# Altium schematic sheet MinSys.SchDoc
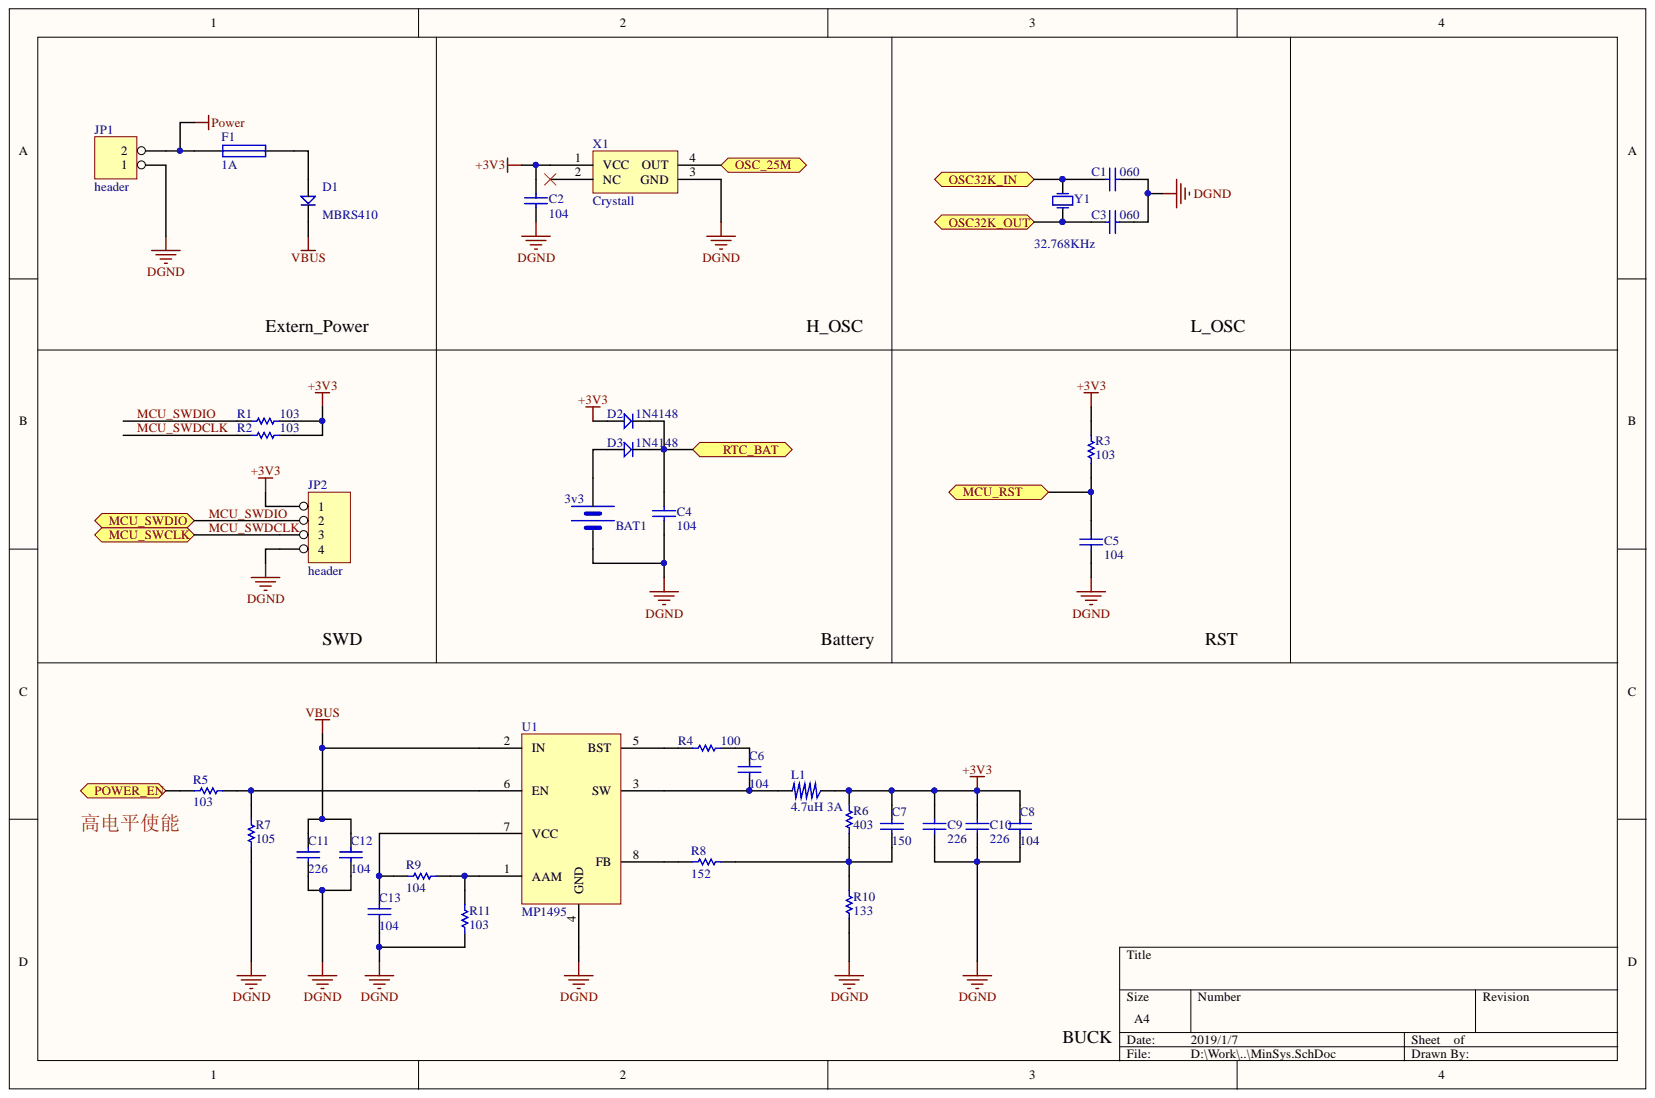
[diagram p0: MinSys.SchDoc]

## Parts
BAT1  3v3  [BATM]
C1  060  [0603_C]
C2  104  [0603_C]
C3  060  [0603_C]
C4  104  [0603_C]
C5  104  [0603_C]
C6  104  [0603_C]
C7  150  [0603_C]
C8  104  [0603_C]
C9  226  [0805_c]
C10  226  [0805_c]
C11  226  [0805_c]
C12  104  [0603_C]
C13  104  [0603_C]
D1  MBRS410  [SMC]
D2  1N4148  [DIODE_SOP]
D3  1N4148  [DIODE_SOP]
F1  1A  [0805]
JP1  header  [header2]
JP2  header  [header4]
L1  4.7uH 3A  [L_CD43]
R1  103  [0603_R]
R2  103  [0603_R]
R3  103  [0603_R]
R4  100  [0603_R]
R5  103  [0603_R]
R6  403  [0603_R]
R7  105  [0603_R]
R8  152  [0603_R]
R9  104  [0603_R]
R10  133  [0603_R]
R11  103  [0603_R]
U1  MP1495  [TSOT23-8]
X1  Crystall  [cpx-49s]
Y1  32.768KHz  [XTAL3215]

## Ports
MCU_RST, MCU_SWCLK, MCU_SWDIO, OSC32K_IN, OSC32K_OUT, OSC_25M, POWER_EN, RTC_BAT

## Nets
+3V3: C2.1 C7.2 C8.2 C9.2 C10.2 D2.1 JP2.1 L1.1 R1.2 R2.2 R3.2 R6.2 X1.1(VCC)
DGND: BAT1.2 C1.2 C2.2 C3.2 C4.1 C5.1 C8.1 C9.1 C10.1 C11.1 C12.1 C13.1 JP1.1 JP2.4 R7.1 R10.1 R11.1 U1.4(GND) X1.3(GND)
POWER: F1.2 JP1.2
VBUS: C11.2 C12.2 D1.2 U1.2(IN)
MCU_RST: C5.2 R3.1 ; port MCU_RST
MCU_SWDCLK: JP2.3 R2.1 ; port MCU_SWCLK
MCU_SWDIO: JP2.2 R1.1 ; port MCU_SWDIO
OSC32K_IN: C1.1 Y1.2 ; port OSC32K_IN
OSC32K_OUT: C3.1 Y1.1 ; port OSC32K_OUT
OSC_25M: X1.4(OUT) ; port OSC_25M
POWER_EN: R5.2 ; port POWER_EN
RTC_BAT: C4.2 D2.2 D3.2 ; port RTC_BAT
NetBAT1_1: BAT1.1 D3.1
NetC6_1: C6.1 L1.2 U1.3(SW)
NetC6_2: C6.2 R4.2
NetC7_1: C7.1 R6.1 R8.2 R10.2
NetC13_2: C13.2 R9.2 U1.7(VCC)
NetD1_1: D1.1 F1.1
NetR4_1: R4.1 U1.5(BST)
NetR5_1: R5.1 R7.2 U1.6(EN)
NetR8_1: R8.1 U1.8(FB)
NetR9_1: R9.1 R11.2 U1.1(AAM)

Not connected: X1 2(NC)

## Library data of the parts
BAT1 = BAT: Component Kind: Standard; Library Name: 阿波罗STM32F4&F7底板封装库.IntLib
C1 C3 C7 C9-C11 = CAP: Capacitor; Value: 104; Component Kind: Standard; LatestRevisionDate: 17-Jul-2002; LatestRevisionNote: Re-released for DXP Platform.; Library Name: My Footprint.IntLib; PackageReference: RAD-0.3; Published: 8-Jun-2000; Publisher: Altium Limited
C2 C4-C6 C8 C12 C13 = CAP: Capacitor; Component Kind: Standard; LatestRevisionDate: 17-Jul-2002; LatestRevisionNote: Re-released for DXP Platform.; Library Name: My Footprint.IntLib; PackageReference: RAD-0.3; Published: 8-Jun-2000; Value: 104; Publisher: Altium Limited
D1-D3 = D: Component Kind: Standard; Library Name: 阿波罗STM32F4&F7底板封装库.IntLib
F1 = Fuse: Component Kind: Standard; Description: Fuse; LatestRevisionDate: 17-Jul-2002; LatestRevisionNote: Re-released for DXP Platform.; Library Name: My Footprint.IntLib; PackageReference: PIN-W2/E2.8; Published: 8-Jun-2000; Publisher: Altium Limited
JP1 = HEADER 2: Component Kind: Standard; Library Name: My Footprint.IntLib
JP2 = HEADER 4: Component Kind: Standard; Library Name: My Footprint.IntLib
L1 = L_SOP: Component Kind: Standard; Library Name: ATK-7016&7084 7寸RGB屏模块封装库.IntLib
R1-R11 = RES: Resistor; Value: 1K; Component Kind: Standard; Library Name: My Footprint.IntLib; Published: 8-Jun-2000; Revision: July-2002: Re-released for DXP Platform.; Publisher: Altium Limited
X1 = crystal: Component Kind: Standard; Library Name: MySchLib.SchLib
Y1 = XTAL: 普通晶振; Component Kind: Standard; LatestRevisionDate: 17-Jul-2002; LatestRevisionNote: Re-released for DXP Platform.; Library Name: STM32H743核心板封装库.IntLib; Published: 8-Jun-2000; Publisher: Altium Limited
U1 = MP1495: Component Kind: Standard; Library Name: MySchLib.SchLib
